# Altium schematic sheet Fuente_5V .SchDoc
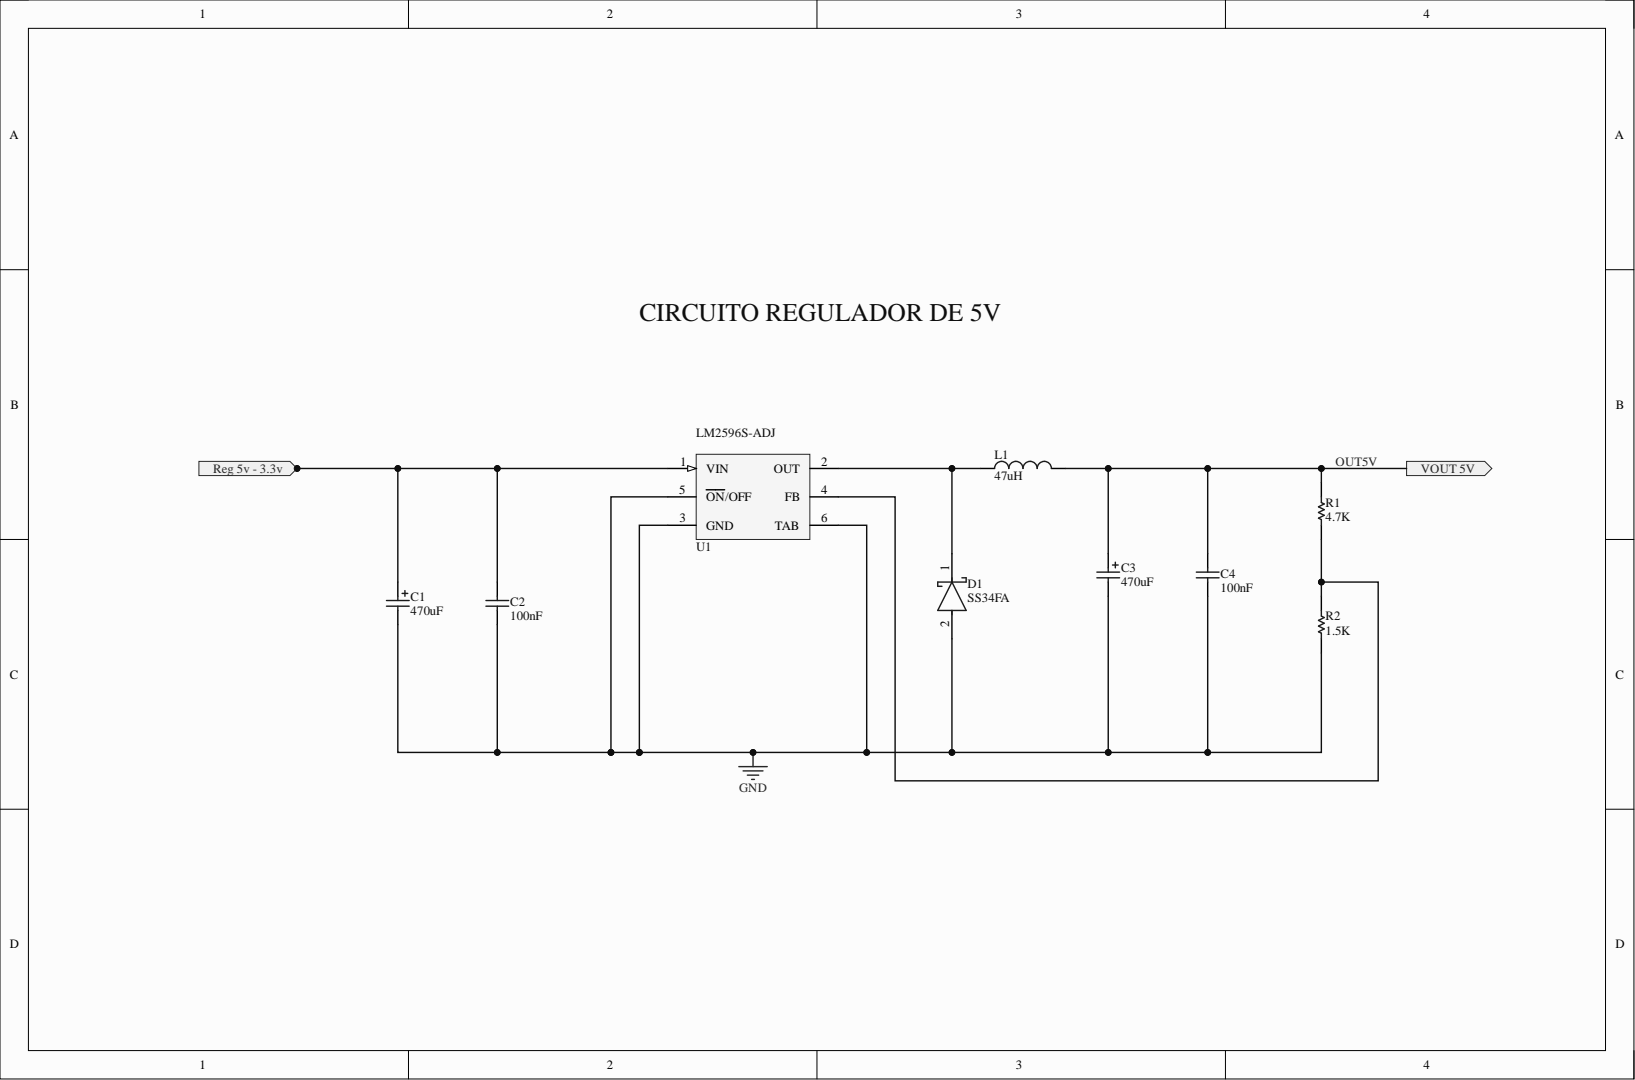
[diagram p0: Fuente_5V .SchDoc]

## Parts
C1  value 470uF  [condensador Al]  (hidden comment: Ceramic)
C2  value 100nF  [CC0805]  (hidden comment: Cap)
C3  value 470uF  [condensador Al]  (hidden comment: Ceramic)
C4  value 100nF  [CC0805]  (hidden comment: Cap)
D1  SS34FA  [Diodo_SS34]
L1  47uH  [inductor 10uH-47uH]
R1  value 4.7K  [RES 1206]  (hidden comment: 1%)
R2  1%  value 1.5K  [RES 1206]
U1  LM2596S-ADJ  [Lm2596-To263]

## Ports
Reg 5v - 3.3v, VOUT 5V

## Nets
GND: C1.2 C2.1 C3.2 C4.1 D1.2 R2.1 U1.3(GND) U1.5(ON/OFF) U1.6(TAB)
OUT5V: C3.1 C4.2 L1.2 R1.2 ; port VOUT 5V
Reg 5v - 3.3v: C1.1 C2.2 U1.1(VIN) ; port Reg 5v - 3.3v
NetD1_1: D1.1 L1.1 U1.2(OUT)
NetR1_1: R1.1 R2.2 U1.4(FB)

## Library data of the parts
C1 C3 = Cap Pol1_1: Polarized Capacitor (Radial); Component Kind: Standard; LatestRevisionDate: 17-Jul-2002; LatestRevisionNote: Re-released for DXP Platform.; PackageDescription: Polarized Capacitor (Radial); 2 Leads; PackageReference: RB7.6-15; Published: 8-Jun-2000; Library Name: biblioteca esquematico sistema de drenaje.SchLib; Publisher: Altium Limited
C2 C4 = Cap_1: Capacitor; Component Kind: Standard; LatestRevisionDate: 17-Jul-2002; LatestRevisionNote: Re-released for DXP Platform.; PackageDescription: Radial Cap, Thru-Hole; 2 Leads; 0.3 in Pin Spacing; PackageReference: RAD-0.3; Published: 8-Jun-2000; Library Name: biblioteca esquematico sistema de drenaje.SchLib; Publisher: Altium Limited
D1 = SS34FA: DIODE SCHOTTKY 40V 3A SOD123W; Component Kind: Standard; RoHS Compliant: Yes; Library Name: biblioteca esquematico sistema de drenaje.SchLib
L1 = Inductor: Code_IEC: 1005; Value: 4A; Code_JEITA: 0402; Component Kind: Standard; Description: Inductor; LatestRevisionDate: 29-May-2009; LatestRevisionNote: IPC-7351 Footprint Added.; PackageDescription: Chip Inductor; PackageReference: 0402-A; Published: 8-Jun-2000; Library Name: biblioteca esquematico sistema de drenaje.SchLib; Publisher: Altium Limited
R1 R2 = Resistor: Component Kind: Standard; Description: Resistor; LatestRevisionDate: 17-Jul-2002; LatestRevisionNote: Re-released for DXP Platform.; PackageDescription: Axial Device, Thru-Hole; 2 Leads; 0.3 in Pin Spacing; PackageReference: AXIAL-0.3; Published: 8-Jun-2000; Library Name: biblioteca esquematico sistema de drenaje.SchLib; Publisher: Altium Limited
U1 = LM2596S-ADJ: SIMPLE SWITCHER® Power Converter 150 KHz 3A Step-Down Voltage Regulator, 5-pin TO-263; Manufacturer: National Semiconductor; Manufacturer Part Number: LM2596SADJ; PackageTopMarking: NSUZXYTTE#*LM2596S*-ADJ P+; PowerWise: N; Code_JEDEC: MO-169-AB; Component Kind: Standard; ComponentLink1Description: LM2596 Datasheet; ComponentLink1URL: http://www.national.com/ds/LM/LM2596.pdf; ComponentLink2Description: LM2596 Web Page; ComponentLink2URL: http://www.national.com/pf/LM/LM2596.html; ComponentLink3Description: TS5B Package Page; ComponentLink3URL: http://www.national.com/packaging/folders/ts5b.html; CompType: Switcher; DatasheetVersion: May-2002; PackageDescription: TO-263, Molded, .400 x .390 x .180in, 5 Lead, .067in Pitch, Surface Mount; PackageReference: TS5B; PackageVersion: revD, Apr-2005; PinCount: 5; RevisionNote: Component URLs added; RoHS: N; Library Name: PCB_Proyecto_Fuente_Voltaje.SCHLIB
